# Altium schematic sheet Sheet1.SchDoc(Sheet1)
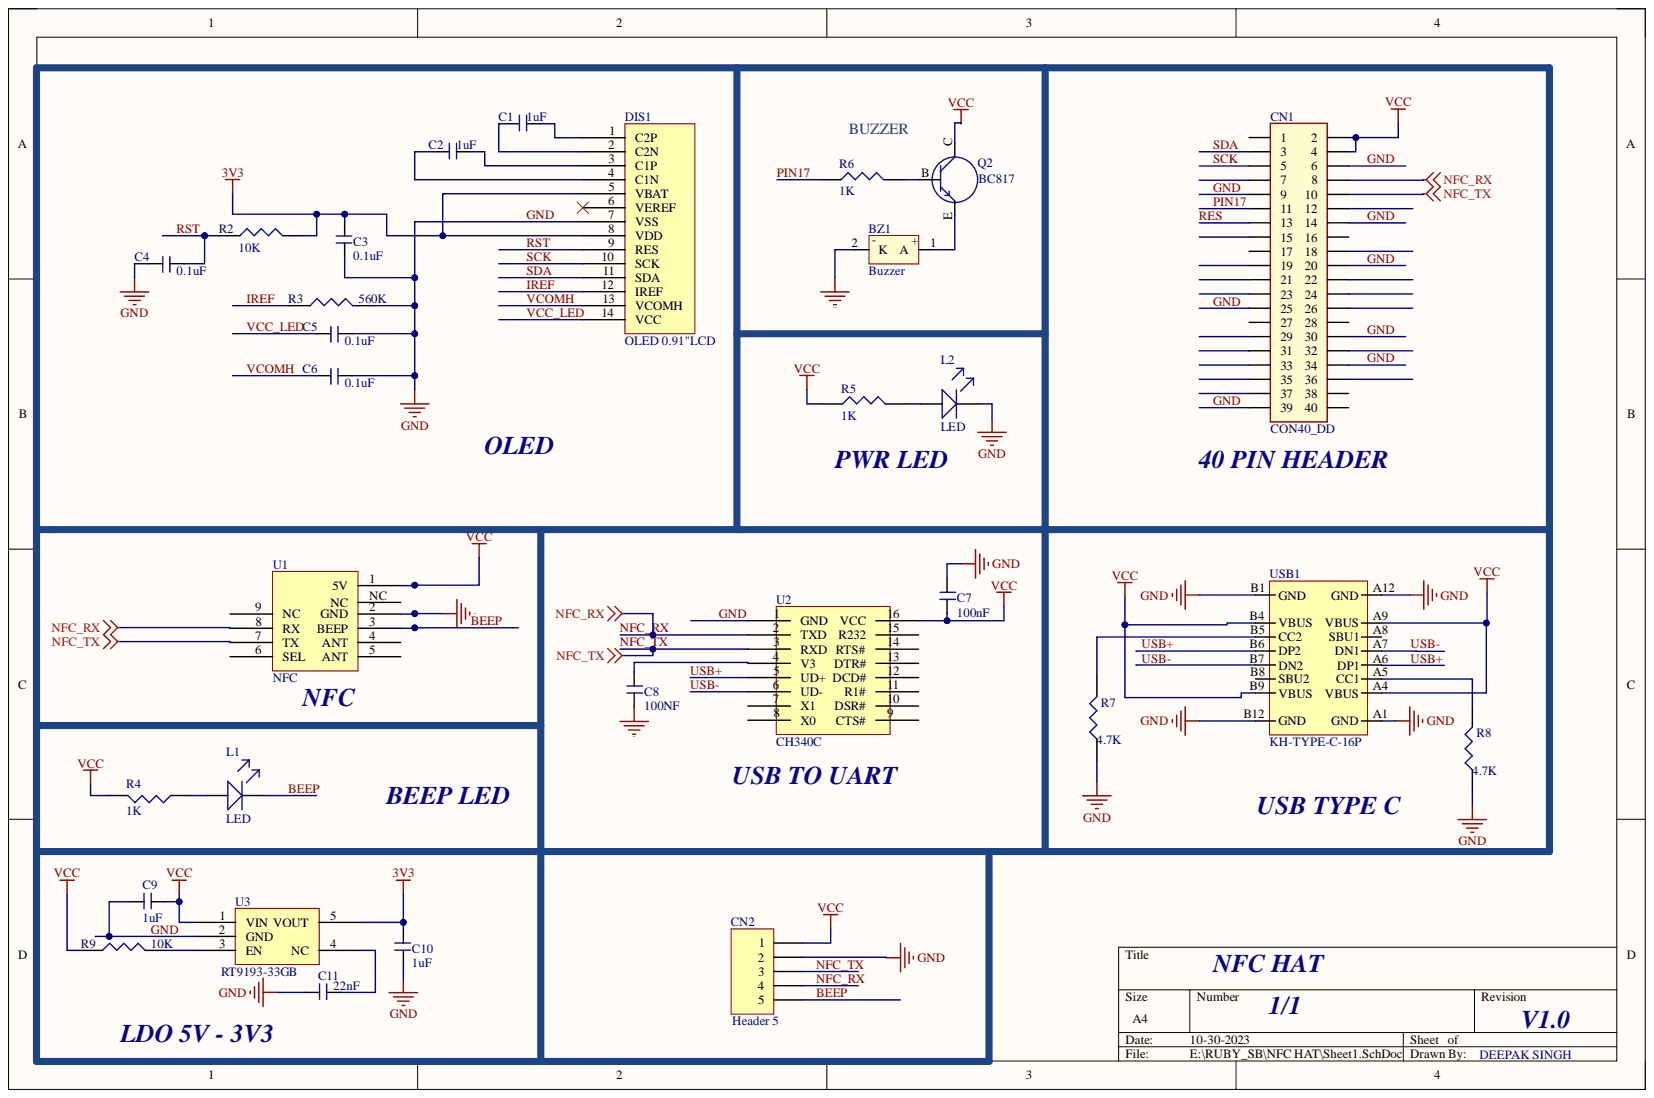
[diagram p0: Sheet1.SchDoc]

## Parts
BZ1  Buzzer  [Buzzer]
C1  1uF  [CAP_0603_NONPOLAR]
C2  1uF  [CAP_0603_NONPOLAR]
C3  0.1uF  [CAP_0603_NONPOLAR]
C4  0.1uF  [CAP_0603_NONPOLAR]
C5  0.1uF  [CAP_0603_NONPOLAR]
C6  0.1uF  [CAP_0603_NONPOLAR]
C7  100nF  [C_0603]
C8  100NF  [C_0603]
C9  1uF  [C_0603]
C10  1uF  [C_0603]
C11  22nF  [C_0603]
CN1  CON40_DD  [CON40_DD_2p5mm_BOT]  (value not drawn)
CN2  Header 5  [HDR1X5]
DIS1  OLED 0.91"LCD  [OLED 0.91 LCD]
L1  LED  [LED_0603_neg]
L2  LED  [LED_0603_neg]
Q2  BC817  [SOT23]
R2  10K  [0603]
R3  560K  [0603]
R4  1K  [0603]
R5  1K  [0603]
R6  1K  [R_0603]
R7  4.7K  [R_0603]
R8  4.7K  [R_0603]
R9  10K  [R_0603]
U1  NFC  [RFID]
U2  CH340C  [SOIC_16]
U3  RT9193-33GB  [SOT23-5]
USB1  KH-TYPE-C-16P  [USB TYPE _C]

## Nets
3V3: C3.1 C10.1 DIS1.5(VBAT) DIS1.8(VDD) R2.2 U3.5(VOUT)
VCC: C7.1 C9.1 CN1.2 CN1.4 CN2.1 Q2.C R4.2 R5.1 R9.1 U1.1(5V) U2.16(VCC) U3.1(VIN) USB1.A4(VBUS) USB1.A9(VBUS) USB1.B4(VBUS) USB1.B9(VBUS)
BEEP: CN2.5 L1.K U1.3(BEEP)
GND: BZ1.2 C3.2 C4.1 C5.2 C6.2 C7.2 C8.1 C9.2 C10.2 C11.2 CN1.6 CN1.9 CN1.14 CN1.20 CN1.25 CN1.30 CN1.34 CN1.39 CN2.2 DIS1.7(VSS) L2.K R3.2 R7.1 R8.1 U1.2(GND) U2.1(GND) U3.2(GND) USB1.A1(GND) USB1.A12(GND) USB1.B1(GND) USB1.B12(GND)
IREF: DIS1.12(IREF) R3.1
NFC_RX: CN1.8 CN2.4 U1.8(RX) U2.2(TXD)
NFC_TX: CN1.10 CN2.3 U1.7(TX) U2.3(RXD)
PIN17: CN1.11 R6.1
RES: CN1.13
RST: C4.2 DIS1.9(RES) R2.1
SCK: CN1.5 DIS1.10(SCK)
SDA: CN1.3 DIS1.11(SDA)
USB+: U2.5(UD+) USB1.A6(DP1) USB1.B6(DP2)
USB-: U2.6(UD-) USB1.A7(DN1) USB1.B7(DN2)
VCC_LED: C5.1 DIS1.14(VCC)
VCOMH: C6.1 DIS1.13(VCOMH)
NetBZ1_1: BZ1.1 Q2.E
NetC1_1: C1.1 DIS1.1(C2P)
NetC1_2: C1.2 DIS1.2(C2N)
NetC2_1: C2.1 DIS1.3(C1P)
NetC2_2: C2.2 DIS1.4(C1N)
NetC8_2: C8.2 U2.4(V3)
NetC11_1: C11.1 U3.4(NC)
NetL1_A: L1.A R4.1
NetL2_A: L2.A R5.2
NetQ2_B: Q2.B R6.2
NetR7_2: R7.2 USB1.B5(CC2)
NetR8_2: R8.2 USB1.A5(CC1)
NetR9_2: R9.2 U3.3(EN)

Not connected: CN1 1 7 12 15 16 17 18 19 21 22 23 24 26 27 28 29 31 32 33 35 36 37 38 40; DIS1 6(VEREF); U1 4(ANT) 5(ANT) 6(SEL) 9(NC) NC; U2 7(X1) 8(X0) 9(CTS#) 10(DSR#) 11(R1#) 12(DCD#) 13(DTR#) 14(RTS#) 15(R232); USB1 A8(SBU1) B8(SBU2)

## Library data of the parts
C1-C11 = CAP_NONPOLAR: Component Kind: Standard; Library Name: dxp_pasl_lib.IntLib
CN2 = Header 5: Header, 5-Pin; Component Kind: Standard; LatestRevisionDate: 17-Jul-2002; LatestRevisionNote: Re-released for DXP Platform.; Library Name: Miscellaneous Connectors.IntLib; Signal Integrity: Connector; Publisher: Altium Limited
DIS1 = OLED 0.91"LCD: OLED I2C Display, 128x32 I2C OLED Display; Component Kind: Standard; Library Name: dxp_pasl_lib.IntLib
Q2 = TRANSISTER_NPN: Component Kind: Standard; Library Name: dxp_pasl_lib.IntLib
R2-R9 = RES: Component Kind: Standard; Library Name: dxp_pasl_lib.IntLib
U1 = NFC: 8MHz XO (Standard) CMOS Oscillator 3.3V Standby (Power Down) 4-SMD, No Lead; Component Kind: Standard; Library Name: MIX.SchLib
U3 = AP2112: 600mA CMOS LDO REGULATOR WITH ENABLE SOT-23-5 PACKAGE; Component Kind: Standard; Library Name: dxp_pasl_lib.IntLib
USB1 = USB TYPE-C KH-TYPE-C-16P: 3A 1 Surface Mount 16 Female -40?~+85? Type-C SMD USB Connectors ROHS #  C709357; Component Kind: Standard; ComponentLink2Description: New link; ComponentLink2URL: https://lcsc.com/product-detail/USB-Connectors_Shenzhen-Kinghelm-Elec-KH-TYPE-C-16P_C709357.html; Supplier 1: LCSC; Supplier Part Number 1: C165948; Library Name: dxp_pasl_lib.IntLib
BZ1 = Buzzer: Component Kind: Standard; Library Name: dxp_pasl_lib.IntLib
CN1 = CON40_DD: Component Kind: Standard; Library Name: dxp_pasl_lib.IntLib
L1 L2 = LED: Component Kind: Standard; Library Name: dxp_pasl_lib.IntLib
U2 = CH340C: Component Kind: Standard; Library Name: dxp_pasl_lib.IntLib
